# Altium schematic sheet Quadop_Algo_Exp_01.SchDoc(Quadop_Algo_Exp_01)
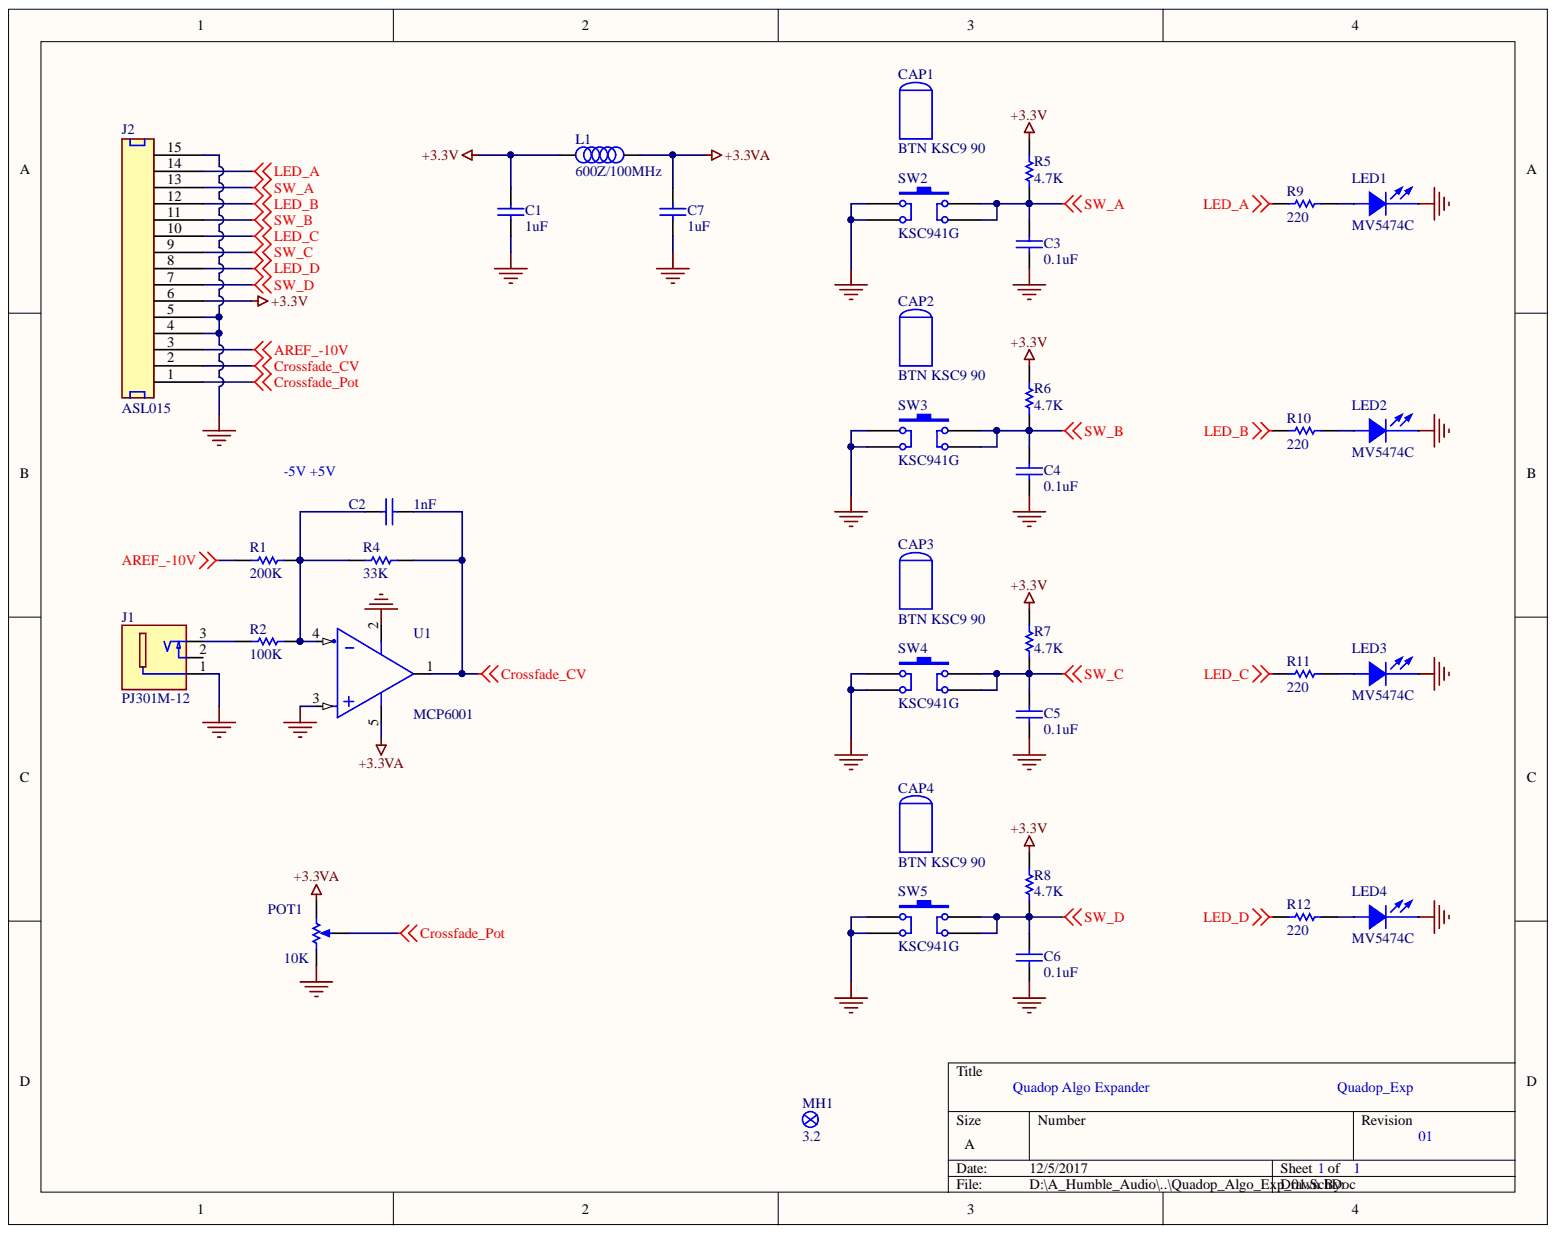
[diagram p0: Quadop_Algo_Exp_01.SchDoc]

## Parts
C1  1uF  [C0603]
C2  1nF  [C0603]
C3  0.1uF  [C0603]
C4  0.1uF  [C0603]
C5  0.1uF  [C0603]
C6  0.1uF  [C0603]
C7  1uF  [C0603]
CAP1  BTN KSC9 90
CAP2  BTN KSC9 90
CAP3  BTN KSC9 90
CAP4  BTN KSC9 90
J1  PJ301M-12  [EP_J_3.5_PJ301M-12]
J2  ASL015  [SL1X15]
L1  600Z/100MHz  [L1608]
LED1  MV5474C  [VDLED2_5XF3.2]
LED2  MV5474C  [VDLED2_5XF3.2]
LED3  MV5474C  [VDLED2_5XF3.2]
LED4  MV5474C  [VDLED2_5XF3.2]
MH1  3.2  [SK_32]
POT1  10K  [POT_RV09ACF-40]
R1  200K  [R0603]
R2  100K  [R0603]
R4  33K  [R0603]
R5  4.7K  [R0603]
R6  4.7K  [R0603]
R7  4.7K  [R0603]
R8  4.7K  [R0603]
R9  220  [R0603]
R10  220  [R0603]
R11  220  [R0603]
R12  220  [R0603]
SW2  KSC941G  [TACT_C_K_KSC941GLFS]
SW3  KSC941G  [TACT_C_K_KSC941GLFS]
SW4  KSC941G  [TACT_C_K_KSC941GLFS]
SW5  KSC941G  [TACT_C_K_KSC941GLFS]
U1  MCP6001  [SOT23_5]

## Nets
+3.3V: C1.1 J2.6 L1.1 R5.1 R6.1 R7.1 R8.1
+3.3VA: C7.1 L1.2 POT1.3 U1.5
AREF_-10V: J2.3 R1.1
Crossfade_CV: C2.2 J2.2 R4.2 U1.1
Crossfade_Pot: J2.1 POT1.2
GND: C1.2 C3.2 C4.2 C5.2 C6.2 C7.2 J1.1 J2.4 J2.5 J2.15 LED1.2 LED2.2 LED3.2 LED4.2 POT1.1 SW2.1 SW2.2 SW3.1 SW3.2 SW4.1 SW4.2 SW5.1 SW5.2 U1.2 U1.3
LED_A: J2.14 R9.1
LED_B: J2.12 R10.1
LED_C: J2.10 R11.1
LED_D: J2.8 R12.1
SW_A: C3.1 J2.13 R5.2 SW2.3 SW2.4
SW_B: C4.1 J2.11 R6.2 SW3.3 SW3.4
SW_C: C5.1 J2.9 R7.2 SW4.3 SW4.4
SW_D: C6.1 J2.7 R8.2 SW5.3 SW5.4
NetC2_1: C2.1 R1.2 R2.2 R4.1 U1.4
NetJ1_3: J1.3 R2.1
NetLED1_1: LED1.1 R9.2
NetLED2_1: LED2.1 R10.2
NetLED3_1: LED3.1 R11.2
NetLED4_1: LED4.1 R12.2

Not connected: J1 2

## Library data of the parts
C1 C7 = 00000550: 1uF 16V 10% X5R 0603 -55+85; Manufacturer Part Number: GRM188R71C105KA12D; Manufacturer: MURATA; Tolerance: +-10%; PSpice: C; Database Table Name: Capacitor; Voltage: 16V; Value: 1uF; Tape Width: 8mm; SMD: Yes; Price: 0.13; Part Type: Ceramic; Part Number: 00000550; Package: 4000T&R; Distributor Part Number: 490-3900-1-ND; Distributor: Digi-Key; Component Kind: Standard; Availability: In Stock; Library Name: Gedo_DB.DbLib
C2 = 00102502: 1nF 50V 5% C0G 0603 -55+125; Manufacturer Part Number: C1608C0G1H102J080AA; Manufacturer: TDK; Tolerance: +-5%; PSpice: C; Database Table Name: Capacitor; Voltage: 50V; Value: 1nF; Tape Width: 8mm; SMD: Yes; Price: 0.0358; Part Type: Ceramic; Part Number: 00102502; Package: 4000T&R; Distributor Part Number: 445-1293-1-ND; Distributor: Digi-Key; Component Kind: Standard; Library Name: Gedo_DB.DbLib
C3-C6 = 00000538: 100nF 50V +-10% X7R 0603 -55+125; Manufacturer Part Number: GRM188R71H104KA93J; Manufacturer: MURATA; PSpice: C; Lead time: Stock; Database Table Name: Capacitor; Voltage: 50V; Value: 0.1uF; Tolerance: +-10%; Tape Width: 8mm; SMD: Yes; Price: 0.0048; Part Type: Ceramic; Part Number: 00000538; Package: 10000T&R330mm; MOQ: 4000; Main distributor: Rutronik; Distributor: Rutronik; Component Kind: Standard; Availability: In Stock; ActivepartsID: http://search.murata.co.jp/Ceramy/GrsearchViewAction.do;jsessionid=C4D720578E4D2E51EC807AFF78C1B5C3; Library Name: Gedo_DB.DbLib
CAP1-CAP4 = 88000046: Tact Sitch Cap, KSC9 series C&K, Snap fit, D=6mm, L=10.2, Switch height 15.4; Database Table Name: Misc; Value: BTN KSC9 90; SMD: Yes; Price: 0.3; Part Type: Switch\Tact Cap; Part Number: 88000046; MOQ: 0; Manufacturer Part Number: BTN KSC9 90; Manufacturer: C&K; Distributor Part Number: CKN10426-ND; Distributor: Digi-Key; Component Kind: Standard; Library Name: Gedo_DB.DbLib
J1 = 72502011: 3pin EARPHONE JACK PANEL MOUNT VERTICAL F3.5; 0.5A 30V; Manufacturer: Thonkiconn; Database Table Name: Connectors; Value: PJ301M-12; Price: 0.48; Part Type: EarPhone jack F3.5; Part Number: 72502011; Manufacturer Part Number: PJ301M-12; Distributor: THONKICONN; Component Kind: Standard; Library Name: Gedo_DB.DbLib
J2 = 71001115: Header 15x2,54mm 250V,3A; Manufacturer Part Number: PRPC015SAAN-RC; Manufacturer: Sullins Connector Solutions; Database Table Name: Connectors; Value: ASL015; Rating: 250V; Price: 0.34; Part Type: 2.54 header; Part Number: 71001115; Distributor Part Number: S1011EC-15-ND; Distributor: Digi-Key; Component Kind: Standard; Library Name: Gedo_DB.DbLib
L1 = 20000006: Chip Bead 600Ωs/100MHz 0.5A Rdc=0.38Ω; 0603; Manufacturer Part Number: BLM18AG601SN1D; Manufacturer: Murata; PSpice: L; Database Table Name: Inductors; Value: 600Z/100MHz; SMD: Yes; Price: 0.07; Part Type: Ferrite beads; Part Number: 20000006; Distributor Part Number: 1515679; Distributor: Farnell; Component Kind: Standard; Library Name: Gedo_DB.DbLib
LED1-LED4 = 32010223: Green Diff LED 3mm 565nm 2V@10mA 9mcd T-1; Manufacturer: Everlight Electronics Co Ltd; PSpice: D; Database Table Name: Diodai; Value: MV5474C; Price: 0.166; Part Type: LED; Part Number: 32010223; Manufacturer Part Number: MV5474C; Distributor Part Number: 1080-1115-ND; Distributor: Digi-Key; Component Kind: Standard; Library Name: Gedo_DB.DbLib
MH1 = 91000103: PCB Mouting hole 3.2  neperkamas; Database Table Name: Misc; Value: 3.2; Part Type: Mechanic\PCB Mouting hole; Part Number: 91000103; MOQ: 0; Component Kind: Standard; Library Name: Gedo_DB.DbLib
POT1 = 18006010: Potentiometer 10K, 9mm, mono,linear,9.5x11.4x27mm; Manufacturer Part Number: P0915N-FC20BR10K; Manufacturer: BI Technologies / TT Electronics; Tolerance: 20%; PSpice: R; Power: 0.05W; Database Table Name: Resistor; Value: 10K; Price: 1.03; Part type: Trimmer; Part Number: 18006010; Distributor Part Number: 858-P0915N-FC20BR10K; Distributor: Mouser; Component Kind: Standard; Library Name: Gedo_DB.DbLib
R1 = 11020032: 200K 1% 0603 1/10W 100ppm; Manufacturer: YAGEO; PSpice: R; Database Table Name: Resistor; Value: 200K; Tolerance: 1%; Tape Width: 8mm; SMD: Yes; Power: 1/10W; Part type: SMD 1%; Part Number: 11020032; Package: 5000T&R; Component Kind: Standard; Availability: In Stock; Library Name: Gedo_DB.DbLib
R2 = 11010032: 100K 1% 0603 1/10W 100ppm; Manufacturer Part Number: RC0603FR-07100KL; Manufacturer: YAGEO; PSpice: R; Database Table Name: Resistor; Value: 100K; Tolerance: 1%; Tape Width: 8mm; SMD: Yes; Price: 0.006; Power: 1/10W; Part type: SMD 1%; Part Number: 11010032; Package: 5000T&R; Distributor Part Number: 311-100KHRCT-ND; Distributor: Digi-Key; Component Kind: Standard; Availability: In Stock; Library Name: Gedo_DB.DbLib
R4 = 11033022: 33K 1% 0603 1/10W 100ppm; Manufacturer Part Number: RC0603FR-0733KL; Manufacturer: YAGEO; PSpice: R; Database Table Name: Resistor; Value: 33K; Tolerance: 1%; Tape Width: 8mm; SMD: Yes; Price: 0.0057; Power: 1/10W; Part type: SMD 1%; Part Number: 11033022; Package: 5000T&R; Distributor Part Number: 311-33.0KHRCT-ND; Distributor: Digi-Key; Component Kind: Standard; Availability: In Stock; Library Name: Gedo_DB.DbLib
R5-R8 = 11047012: 4K7 1% 0603 1/10W 100ppm; PSpice: R; Lead time: Stock; Database Table Name: Resistor; Value: 4.7K; Tolerance: 1%; Tape Width: 8mm; SMD: Yes; Power: 1/10W; Part type: SMD 1%; Part Number: 11047012; MOQ: 5000; Main distributor: Rutronik; Component Kind: Standard; Availability: In Stock; Library Name: Gedo_DB.DbLib
R9-R12 = 11022002: 220R 1% 0603 1/10W 100ppm; Manufacturer Part Number: RC0603FR-07220RL; Manufacturer: YAGEO; PSpice: R; Database Table Name: Resistor; Value: 220; Tolerance: 1%; Tape Width: 8mm; SMD: Yes; Price: 0.01; Power: 1/10W; Part type: SMD 1%; Part Number: 11022002; Package: 5000T&R; Distributor Part Number: 311-220HRCT-ND; Distributor: Digi-Key; Component Kind: Standard; Availability: In Stock; Library Name: Gedo_DB.DbLib
SW2-SW5 = 88000045: MINI Tact Switch, SPST-NO, Off-Mom, SMD; 6.2x6.2mm h=7.7, -40+85; Manufacturer Part Number: KSC941GLFS; Manufacturer: C&K; Database Table Name: Misc; Value: KSC941G; Tape Width: 16mm; SMD: Yes; Price: 0.63; Part Type: Switch\Tact; Part Number: 88000045; Package: 750T&R; MOQ: 0; Distributor Part Number: CKN10434CT-ND; Distributor: Digi-Key; Component Kind: Standard; Library Name: Gedo_DB.DbLib
U1 = 51260012: Op amplifier,Rail-to-rail,Vs=1.8-6.0V,1MHz,Vo=0.6V/us,SOT23-5,-40+85; Manufacturer Part Number: MCP6001T-I/OT; Manufacturer: Microchip Technology; Database Table Name: IC; Value: MCP6001; SMD: Yes; Price: 0.2; Part Type: OP Amplifiers; Part Number: 51260012; Package: 3000T&R; Distributor Part Number: MCP6001T-I/OTCT-ND; Distributor: Digi-Key; Component Kind: Standard; Library Name: Gedo_DB.DbLib
